# Altium schematic sheet DS1216E.SchDoc
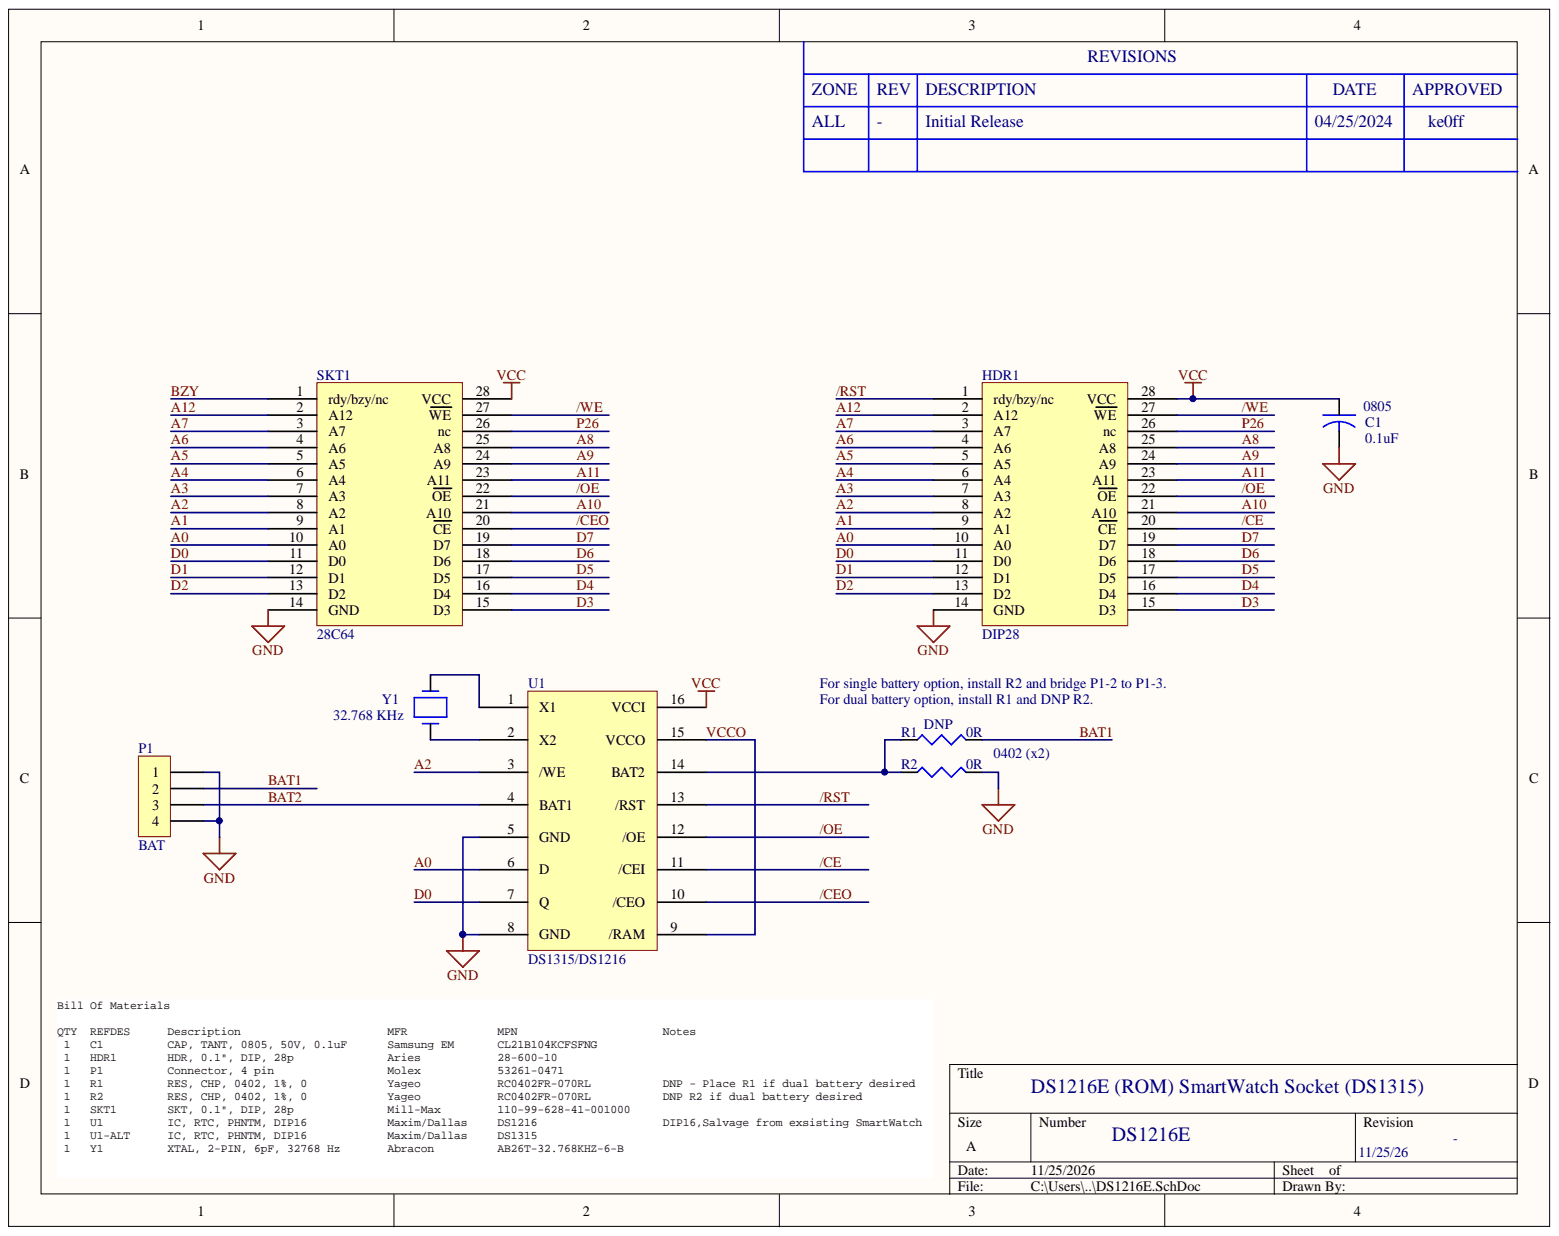
[diagram p0: DS1216E.SchDoc]

## Parts
C1  0.1uF  [0805]
HDR1  DIP28  [DIP28]
P1  BAT  [CON_1.25MM_4P]
R1  0R  [0402]
R2  0R  [0402]
SKT1  28C64  [DIP28]
U1  DS1315/DS1216  [DIP16]
Y1  32.768 KHz  [XTAL_AB26TRB]

## Nets
GND: C1.2 HDR1.14(GND) P1.1 P1.4 R2.2 SKT1.14(GND) U1.5(GND) U1.8(GND)
VCC: C1.1 HDR1.28(VCC) SKT1.28(VCC) U1.16(VCCI)
/CE: HDR1.20(CE) U1.11(/CEI)
/CEO: SKT1.20(CE) U1.10(/CEO)
/OE: HDR1.22(OE) SKT1.22(OE) U1.12(/OE)
/RST: HDR1.1(rdy/bzy/nc) U1.13(/RST)
/WE: HDR1.27(WE) SKT1.27(WE)
A0: HDR1.10(A0) SKT1.10(A0) U1.6(D)
A1: HDR1.9(A1) SKT1.9(A1)
A2: HDR1.8(A2) SKT1.8(A2) U1.3(/WE)
A3: HDR1.7(A3) SKT1.7(A3)
A4: HDR1.6(A4) SKT1.6(A4)
A5: HDR1.5(A5) SKT1.5(A5)
A6: HDR1.4(A6) SKT1.4(A6)
A7: HDR1.3(A7) SKT1.3(A7)
A8: HDR1.25(A8) SKT1.25(A8)
A9: HDR1.24(A9) SKT1.24(A9)
A10: HDR1.21(A10) SKT1.21(A10)
A11: HDR1.23(A11) SKT1.23(A11)
A12: HDR1.2(A12) SKT1.2(A12)
BAT1: P1.2 R1.2
BAT2: P1.3 U1.4(BAT1)
BZY: SKT1.1(rdy/bzy/nc)
D0: HDR1.11(D0) SKT1.11(D0) U1.7(Q)
D1: HDR1.12(D1) SKT1.12(D1)
D2: HDR1.13(D2) SKT1.13(D2)
D3: HDR1.15(D3) SKT1.15(D3)
D4: HDR1.16(D4) SKT1.16(D4)
D5: HDR1.17(D5) SKT1.17(D5)
D6: HDR1.18(D6) SKT1.18(D6)
D7: HDR1.19(D7) SKT1.19(D7)
P26: HDR1.26(nc) SKT1.26(nc)
VCCO: U1.9(/RAM) U1.15(VCCO)
NetR1_1: R1.1 R2.1 U1.14(BAT2)
NetU1_1: U1.1(X1) Y1.2
NetU1_2: U1.2(X2) Y1.1

## Library data of the parts
C1 = CAP 0805: CAP, TANT, 0805, 50V, 0.1uF; MFR: Samsung EM; MPN: CL21B104KCFSFNG; ALT-MFR: -; ALT-MPN: -; Component Kind: Standard; DNP: -; Library Name: Galaxy SCH-jem-1.08.SchLib
HDR1 = 28C64: HDR, 0.1", DIP, 28p; MFR: Aries; MPN: 28-600-10; ALT-MPN: -; Component Kind: Standard; DNP: -; NOTES: -; NOTES2: -; PRI-MPN: -; Library Name: Galaxy SCH-jem-1.08.SchLib
P1 = CON4: Connector, 4 pin; MFR: Molex; MPN: 053398-0471; MPN-ALT: 53261-0471; NOTES: DNP if hardwire to battery (use pins 3&4 for single battery connection); NOTES2: 53261-0471 = right/angle, 53398-0471 = vertical; Component Kind: Standard; DNP: -; MFR-ALT: Molex; PRI-MPN: -; Library Name: Galaxy SCH-jem-1.08.SchLib
R1 = R0201: RES, CHP, 0402, 1%, 0; MFR: Yageo; MPN: RC0402FR-070RL; NOTES: Place R1 if dual battery desired; ALT-MPN: -; Component Kind: Standard; DNP: DNP; NOTES2: -; PRI-MPN: -; Library Name: Galaxy SCH-jem-1.08.SchLib
R2 = R0201: RES, CHP, 0402, 1%, 0; MFR: Yageo; MPN: RC0402FR-070RL; NOTES: DNP R2 if dual battery desired; ALT-MPN: -; Component Kind: Standard; DNP: -; NOTES2: -; PRI-MPN: -; Library Name: Galaxy SCH-jem-1.08.SchLib
SKT1 = 28C64: SKT, 0.1", DIP, 28p; MFR: Mill-Max; MPN: 110-99-628-41-001000; ALT-MPN: -; Component Kind: Standard; DNP: -; NOTES: -; NOTES2: -; PRI-MPN: -; Library Name: Galaxy SCH-jem-1.08.SchLib
U1 = DS1315: IC, RTC, PHNTM, DIP16; MFR: Maxim/Dallas; MPN: DS1216; NOTES: Salvage from exsisting SmartWatch; ALT-MPN: DS1315; Component Kind: Standard; DNP: -; NOTES2: -; PRI-MPN: -; Library Name: Galaxy SCH-jem-1.08.SchLib
Y1 = CRYSTAL-2PIN: XTAL, WTCH, 2-PIN, 6pF, 32768 Hz; MFR: Abracon; MPN: AB26T-32.768KHZ-6-B; ALT-MPN: -; Component Kind: Standard; DNP: -; NOTES: -; NOTES2: -; PRI-MPN: -; Library Name: Galaxy SCH-jem-1.08.SchLib
